# Altium schematic sheet matrix_right.SchDoc
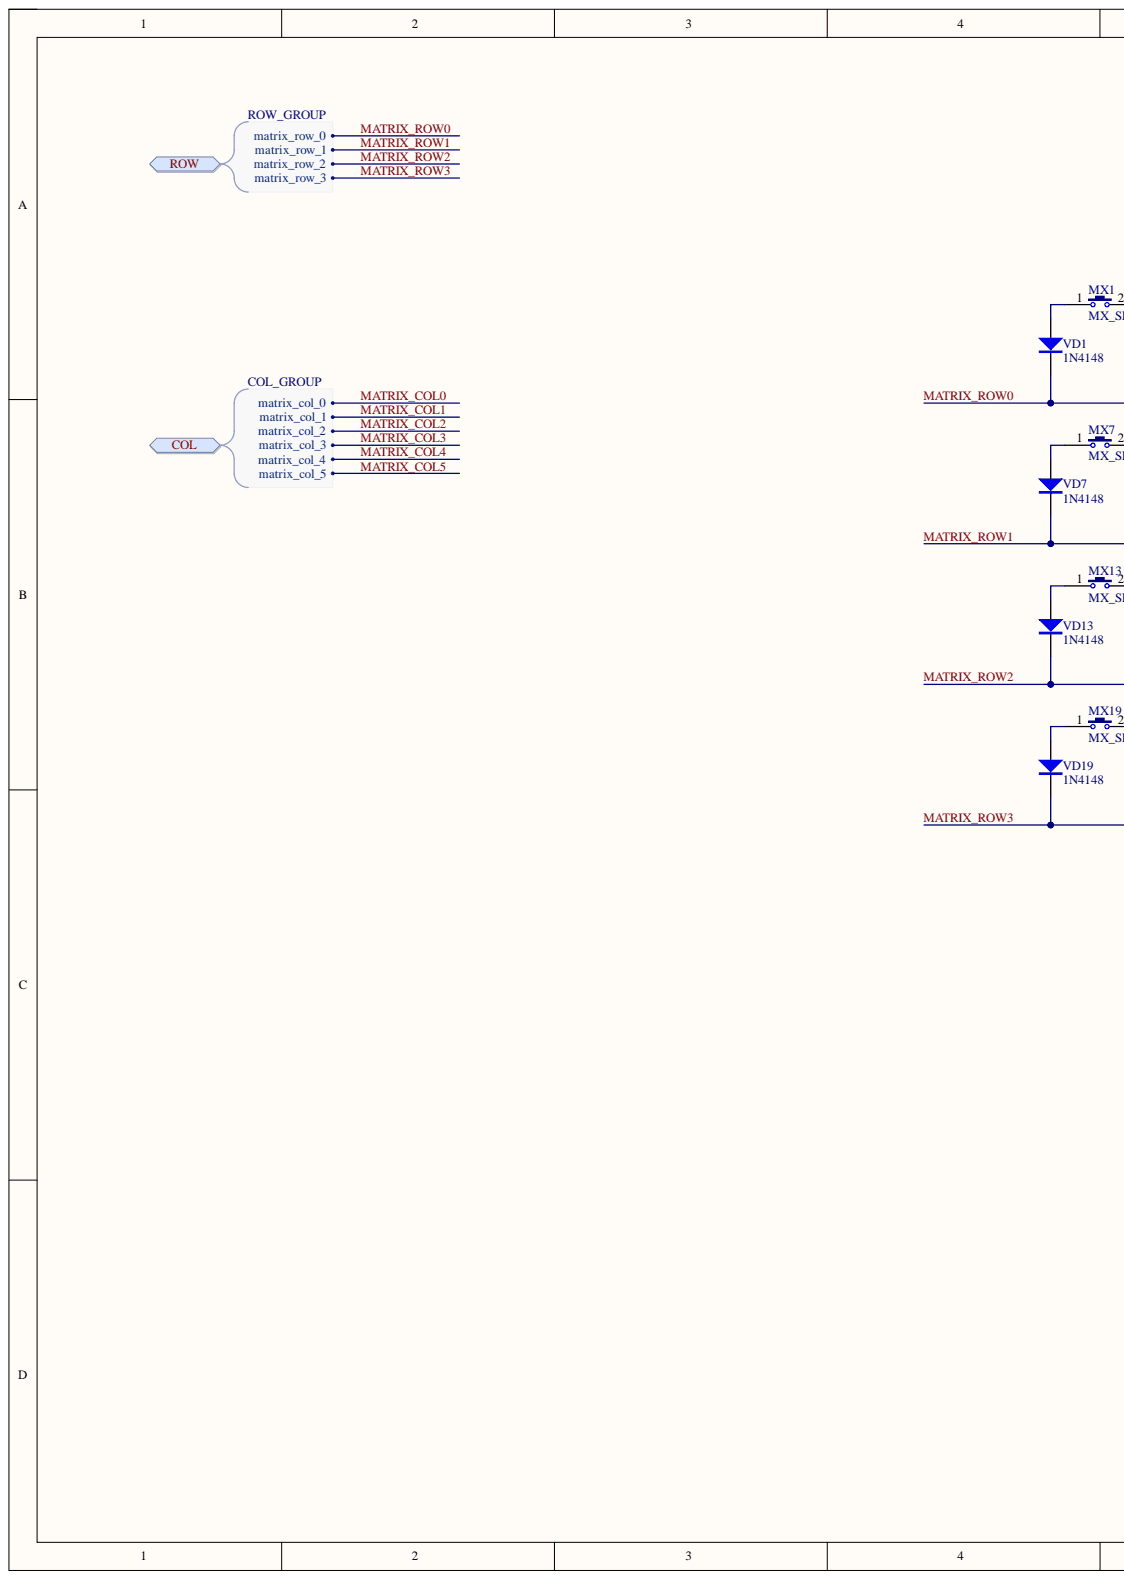
[diagram p0: matrix_right.SchDoc, left]
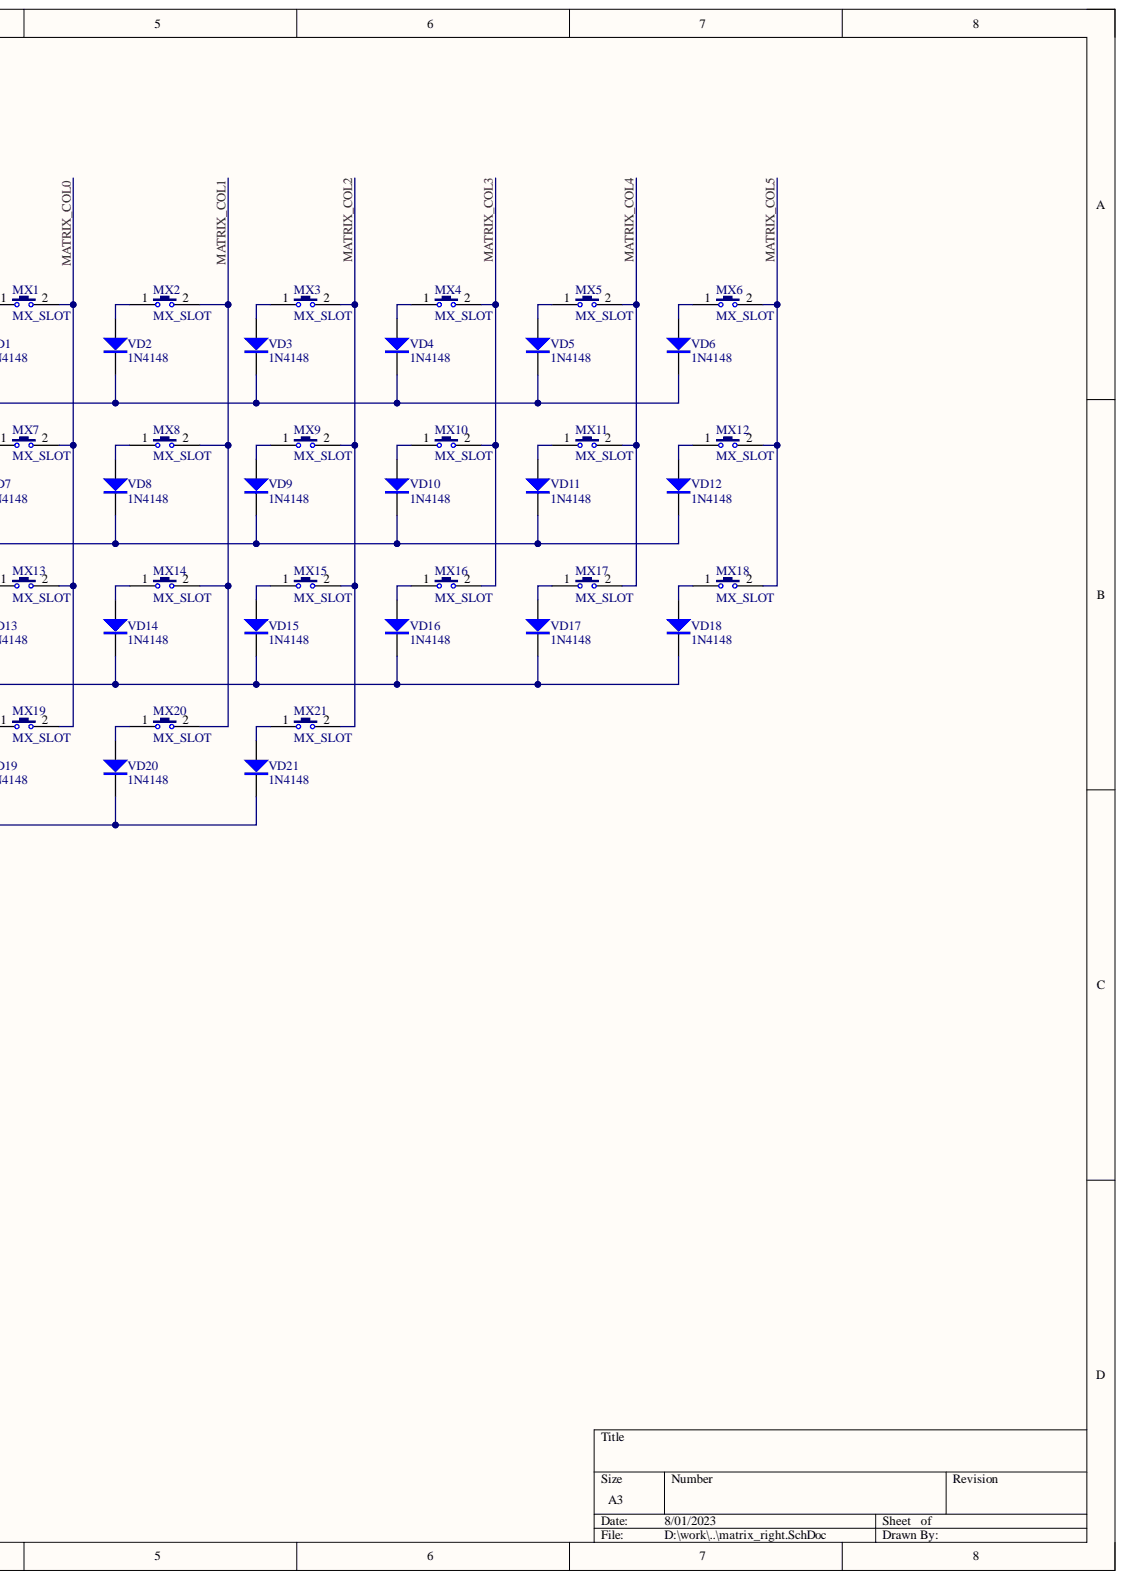
[diagram p1: matrix_right.SchDoc, right]

## Parts
MX1  MX_SLOT  [GATERON_MX_SLOT]
MX2  MX_SLOT  [GATERON_MX_SLOT]
MX3  MX_SLOT  [GATERON_MX_SLOT]
MX4  MX_SLOT  [GATERON_MX_SLOT]
MX5  MX_SLOT  [GATERON_MX_SLOT]
MX6  MX_SLOT  [GATERON_MX_SLOT]
MX7  MX_SLOT  [GATERON_MX_SLOT]
MX8  MX_SLOT  [GATERON_MX_SLOT]
MX9  MX_SLOT  [GATERON_MX_SLOT]
MX10  MX_SLOT  [GATERON_MX_SLOT]
MX11  MX_SLOT  [GATERON_MX_SLOT]
MX12  MX_SLOT  [GATERON_MX_SLOT]
MX13  MX_SLOT  [GATERON_MX_SLOT]
MX14  MX_SLOT  [GATERON_MX_SLOT]
MX15  MX_SLOT  [GATERON_MX_SLOT]
MX16  MX_SLOT  [GATERON_MX_SLOT]
MX17  MX_SLOT  [GATERON_MX_SLOT]
MX18  MX_SLOT  [GATERON_MX_SLOT]
MX19  MX_SLOT  [GATERON_MX_SLOT]
MX20  MX_SLOT  [GATERON_MX_SLOT]
MX21  MX_SLOT  [GATERON_MX_SLOT]
VD1  1N4148  [SOD-323]
VD2  1N4148  [SOD-323]
VD3  1N4148  [SOD-323]
VD4  1N4148  [SOD-323]
VD5  1N4148  [SOD-323]
VD6  1N4148  [SOD-323]
VD7  1N4148  [SOD-323]
VD8  1N4148  [SOD-323]
VD9  1N4148  [SOD-323]
VD10  1N4148  [SOD-323]
VD11  1N4148  [SOD-323]
VD12  1N4148  [SOD-323]
VD13  1N4148  [SOD-323]
VD14  1N4148  [SOD-323]
VD15  1N4148  [SOD-323]
VD16  1N4148  [SOD-323]
VD17  1N4148  [SOD-323]
VD18  1N4148  [SOD-323]
VD19  1N4148  [SOD-323]
VD20  1N4148  [SOD-323]
VD21  1N4148  [SOD-323]

## Ports
COL, COL.matrix_col_0, COL.matrix_col_1, COL.matrix_col_2, COL.matrix_col_3, COL.matrix_col_4, COL.matrix_col_5, ROW, ROW.matrix_row_0, ROW.matrix_row_1, ROW.matrix_row_2, ROW.matrix_row_3

## Nets
MATRIX_COL0: MX1.2 MX7.2 MX13.2 MX19.2 ; port COL
MATRIX_COL1: MX2.2 MX8.2 MX14.2 MX20.2 ; port COL
MATRIX_COL2: MX3.2 MX9.2 MX15.2 MX21.2 ; port COL
MATRIX_COL3: MX4.2 MX10.2 MX16.2 ; port COL
MATRIX_COL4: MX5.2 MX11.2 MX17.2 ; port COL
MATRIX_COL5: MX6.2 MX12.2 MX18.2 ; port COL
MATRIX_ROW0: VD1.2 VD2.2 VD3.2 VD4.2 VD5.2 VD6.2 ; port ROW
MATRIX_ROW1: VD7.2 VD8.2 VD9.2 VD10.2 VD11.2 VD12.2 ; port ROW
MATRIX_ROW2: VD13.2 VD14.2 VD15.2 VD16.2 VD17.2 VD18.2 ; port ROW
MATRIX_ROW3: VD19.2 VD20.2 VD21.2 ; port ROW
NetMX1_1: MX1.1 VD1.1
NetMX2_1: MX2.1 VD2.1
NetMX3_1: MX3.1 VD3.1
NetMX4_1: MX4.1 VD4.1
NetMX5_1: MX5.1 VD5.1
NetMX6_1: MX6.1 VD6.1
NetMX7_1: MX7.1 VD7.1
NetMX8_1: MX8.1 VD8.1
NetMX9_1: MX9.1 VD9.1
NetMX10_1: MX10.1 VD10.1
NetMX11_1: MX11.1 VD11.1
NetMX12_1: MX12.1 VD12.1
NetMX13_1: MX13.1 VD13.1
NetMX14_1: MX14.1 VD14.1
NetMX15_1: MX15.1 VD15.1
NetMX16_1: MX16.1 VD16.1
NetMX17_1: MX17.1 VD17.1
NetMX18_1: MX18.1 VD18.1
NetMX19_1: MX19.1 VD19.1
NetMX20_1: MX20.1 VD20.1
NetMX21_1: MX21.1 VD21.1

## Library data of the parts
MX1-MX21 = GATERON_MX_SLOT: GATERON佳达隆热插拔底座轴体开关改装配件; Component Kind: Standard; Library Name: Connector.SchLib
VD1-VD21 = 1N4148: 高速开关二极管; 版权归属: 源创客; BOM名称: 高速开关二极管; Component Kind: Standard; Library Name: 二极管-整流桥.SchLib; 学习资源: www.ooocke.com
